# Altium schematic sheet RectSchematic.SchDoc(RectSchematic)
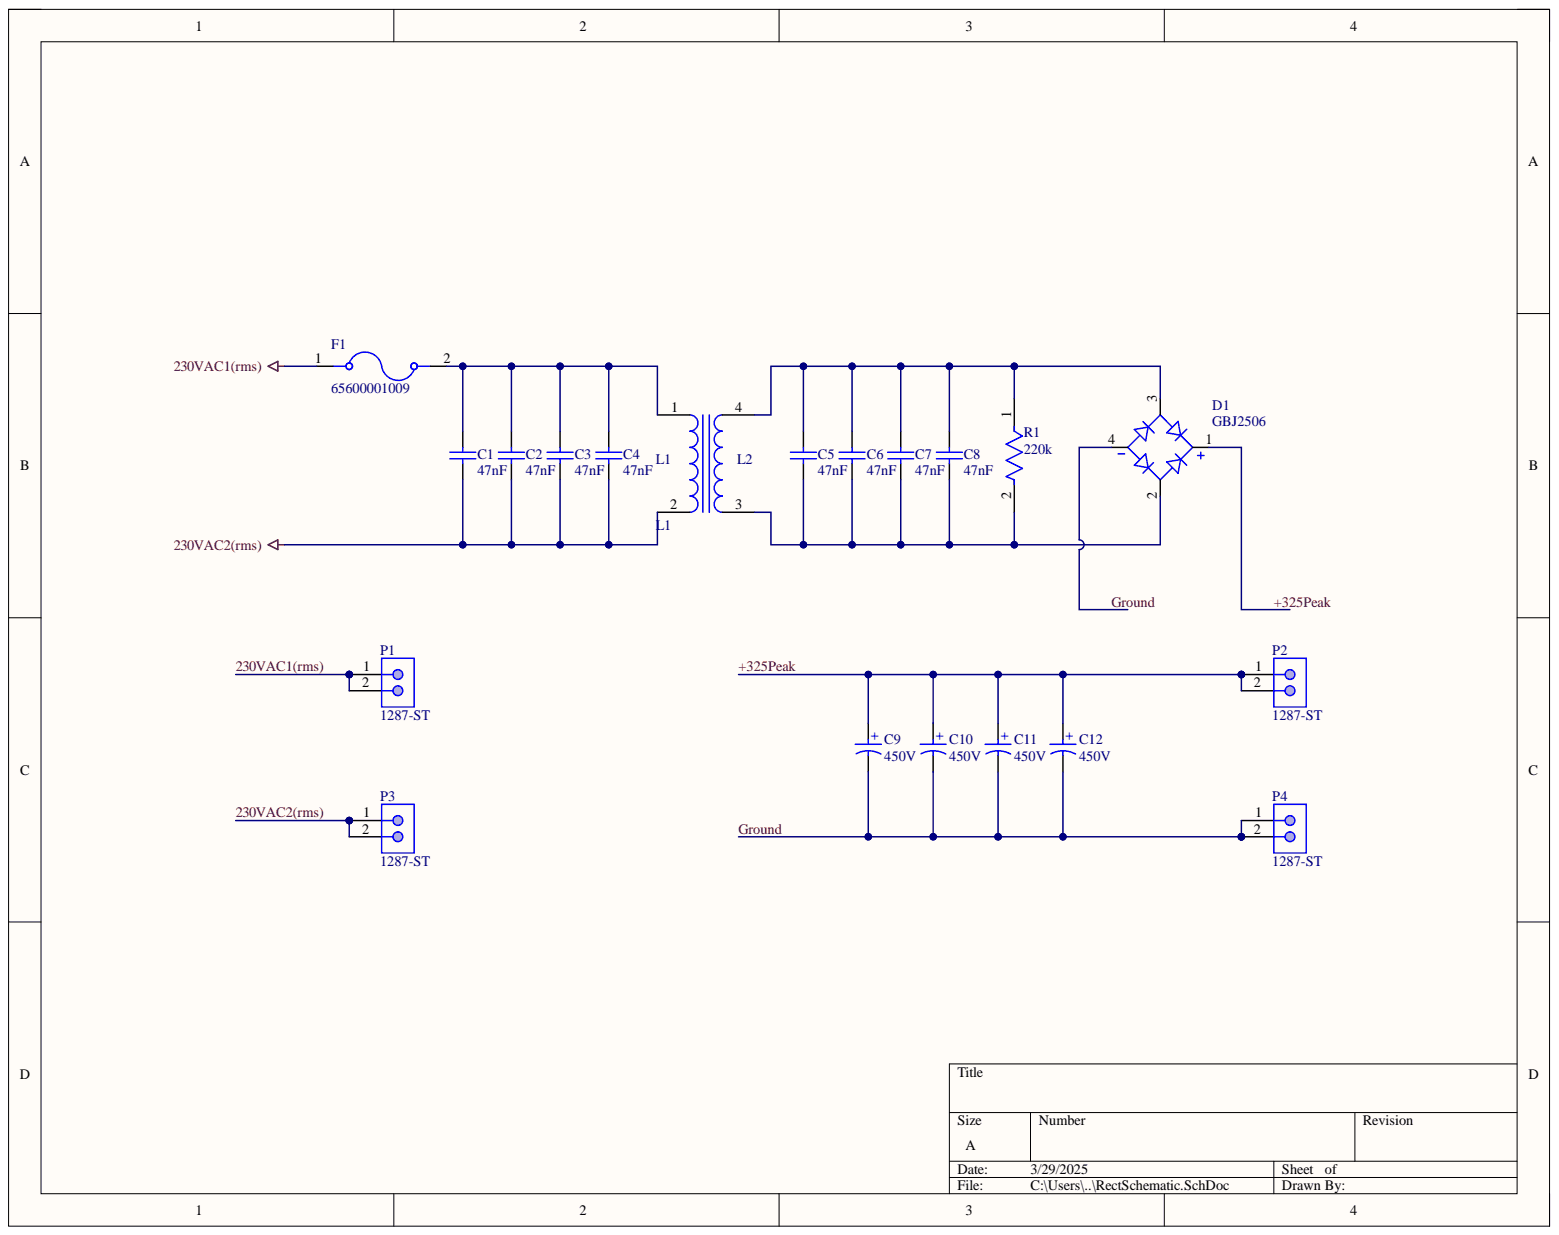
[diagram p0: RectSchematic.SchDoc]

## Parts
C1  47nF  [WCAP-FTX2_L11W6H10_CS]
C2  47nF  [WCAP-FTX2_L11W6H10_CS]
C3  47nF  [WCAP-FTX2_L11W6H10_CS]
C4  47nF  [WCAP-FTX2_L11W6H10_CS]
C5  47nF  [WCAP-FTX2_L11W6H10_CS]
C6  47nF  [WCAP-FTX2_L11W6H10_CS]
C7  47nF  [WCAP-FTX2_L11W6H10_CS]
C8  47nF  [WCAP-FTX2_L11W6H10_CS]
C9  450V  [WCAP-AI3H_D35H55]
C10  450V  [WCAP-AI3H_D35H55]
C11  450V  [WCAP-AI3H_D35H55]
C12  450V  [WCAP-AI3H_D35H55]
D1  GBJ2506  [DIOB_GBJ2506]
F1  65600001009  [FUSE_65600001009]
L1  ET2835-034  [IND_ET2835-034]  (value not drawn)
P1  1287-ST  [KSTN-1287-ST]
P2  1287-ST  [KSTN-1287-ST]
P3  1287-ST  [KSTN-1287-ST]
P4  1287-ST  [KSTN-1287-ST]
R1  220k  [FP-VR37-MFG]

## Nets
230VAC1(rms): F1.1 P1.1 P1.2
230VAC2(rms): C1.1 C2.1 C3.1 C4.1 L1.2 P3.1 P3.2
+325Peak: C9.1 C10.1 C11.1 C12.1 D1.1 P2.1 P2.2
Ground: C9.2 C10.2 C11.2 C12.2 D1.4 P4.1 P4.2
NetC1_2: C1.2 C2.2 C3.2 C4.2 F1.2 L1.1
NetC5_1: C5.1 C6.1 C7.1 C8.1 D1.2 L1.3 R1.2
NetC5_2: C5.2 C6.2 C7.2 C8.2 D1.3 L1.4 R1.1

## Library data of the parts
C1-C8 = CMP-03913-51400082-2: 890324022007CS WCAP-FTX2 Film Capacitor 15nF 275Vac; Dielectric: PP; Hole Diameter: 900µm; Insulation Resistance: 30GΩ; Pin Length: 4mm; Pitch: 7.5mm; Tolerance: 10%; Voltage Rating: 275V; Capacitance: 15nF; Case/Package: Radial; Component Kind: Standard; Dielectric Material: PP; Height: 10mm; Height - Seated (Max): 10.4902mm; Lead Pitch: 7.493mm; Length: 11mm; Library Name: Altium Content Vault; Max Operating Temperature: 105°C; Min Operating Temperature: -40°C; Mount: Through Hole; NexarPartId: 51400082; Packaging: Carton; REACH SVHC: Unknown; RoHS: Compliant; Termination: PC Pins; Voltage Rating (AC): 275V; Voltage Rating (DC): 275V; Width: 6mm
C9-C12 = CMP-03913-54202431-1: 861141486026 WCAP-AI3H Aluminum Electrolytic Capacitors; Capacitance: 680µF; ESR (Equivalent Series Resistance): 210mΩ; Leakage Current: 1.65952mA; Life (Hours): 3000hours; Pitch: 10mm; Polarity: Polar; Ripple Current: 2.2A; Tolerance: 20%; Case/Package: Radial; Component Kind: Standard; Diameter: 35mm; Height: 57mm; Height - Seated (Max): 59.0042mm; Lead Pitch: 10.0076mm; Length: 55mm; Library Name: Altium Content Vault; Max Operating Temperature: 105°C; Min Operating Temperature: -25°C; Mount: Through Hole; NexarPartId: 54202431; REACH SVHC: Unknown; RoHS: Compliant; Voltage Rating: 450V; Voltage Rating (DC): 450V
D1 = CMP-004-00032-1: Bridge Rectifier Single Phase Standard 600 V Through Hole GBJ; MF: Diodes Inc.; Case/Package: SIP-4 Diodes Incorporated; Check_prices: https://www.snapeda.com/parts/GBJ2506/Diodes+Inc./view-part/?ref=eda; Component Kind: Standard; Component Type: Standard; Design Item ID: GBJ2506; MP: GBJ2506; Pins: 4; SnapEDA_Link: https://www.snapeda.com/parts/GBJ2506/Diodes+Inc./view-part/?ref=snap; Source: GBJ2506.SchLib; Library Name: Elyamani's Personal Workspace
F1 = CMP-005-000000-1: Fuse Block 10A 250V 1 Circuit Cartridge Through Hole; MF: Littelfuse Inc.; Component Kind: Standard; Component Type: Standard; Design Item ID: 65600001009; Digi-Key_Part_Number: WK6245-ND; Digi-Key_Purchase_URL: https://www.digikey.com/product-detail/en/littelfuse-inc/65600001009/WK6245-ND/653441?utm_source=snapeda&utm_medium=aggregator&utm_campaign=symbol; MP: 65600001009; Package: None; Pin Count: 2; SnapEDA_Link: https://www.snapeda.com/parts/65600001009/Littelfuse%20Inc./view-part/293355/?ref=snap; Source: SnapEDAsch.SchLib; Library Name: Elyamani's Personal Workspace
L1 = CMP-006-00023-1: 120 mH @ 10 kHz 2 Line Common Mode Choke Through Hole 500mA DCR 2.6Ohm; MF: Triad Magnetics; Case/Package: Vertical-4 Triad Magnetics; Check_prices: https://www.snapeda.com/parts/ET2835-034/Triad+Magnetics/view-part/?ref=eda; Component Kind: Standard; Component Type: Standard; Design Item ID: ET2835-034; MP: ET2835-034; Pins: 4; SnapEDA_Link: https://www.snapeda.com/parts/ET2835-034/Triad+Magnetics/view-part/?ref=snap; Source: ET2835-034.SchLib; Library Name: Elyamani's Personal Workspace
P1-P4 = CMP-2000-05485-1: PC Sturdi-Mount Quick-Fit Terminal, Vertical, Male, 2-Pin THD, Bulk; Manufacturer: Keystone; Angle: Straight; Finish: Tin; Finish Plating: TinPlate; Insulation Type: Non-Insulated; Material, Contact: Brass; Mounting Hole Size: 0.052; Primary Type: QuickDisconnect; Standards: ULListed; Tab Fit: 0.25; Component Kind: Standard; Contact Plating: Tin; Datasheet URL: http://www.keyelco.com/product-pdf.cfm?p=1859; Datasheet Version: Rev. C, 08/2012; Diameter, Hole: 0.052in.; Gender: Male; Length, Overall: 0.552"; Library Name: Altium Content Vault; Manufacturer URL: http://www.keyelco.com/; Material: .032[.81]Brass; Mount Angle: Straight; Mounting: VERTICAL; Mounting Technology: ThroughHole; Orientation: VERTICAL-Sturdi-Mount; Package Description: 2-Leads Quick-Fit Terminal, Pitch 5.08 mm; Package Reference: 1287-ST; Package URL: http://www.keyelco.com/product-pdf.cfm?p=1859; Terminal Style: PCBMale; Terminal Type: Quick-Fit; Termination: Solder; Type: Tab; Width, Overall: 0.312"
R1 = CMP-02408-000054-1: RES 1.2M OHM 5% 1/2W AXIAL; Power Rating: 500mW; Resistance: 220Kohm; Temperature Coefficient: 200ppm/°C; Voltage Rating: 3.5kV; Case/Package: Conformal; Component Kind: Standard; Construction: Cylindrical; Contact Plating: Tin; Diameter: 4mm; Length: 10mm; Library Name: Altium Content Vault; Max Operating Temperature: 155°C; Military Standard: Not; Min Operating Temperature: -55°C; Mount: Through Hole; Packaging: Ammo Pack; Radiation Hardening: No; Reach SVHC Compliant: Yes; Resistance Tolerance: 5%; Resistor Type: Metal Film; Termination: Axial; Tolerance: 5%; Voltage Rating (DC): 3.5kV
